# Altium schematic sheet Digital_Clock_SCH_2.0.SchDoc
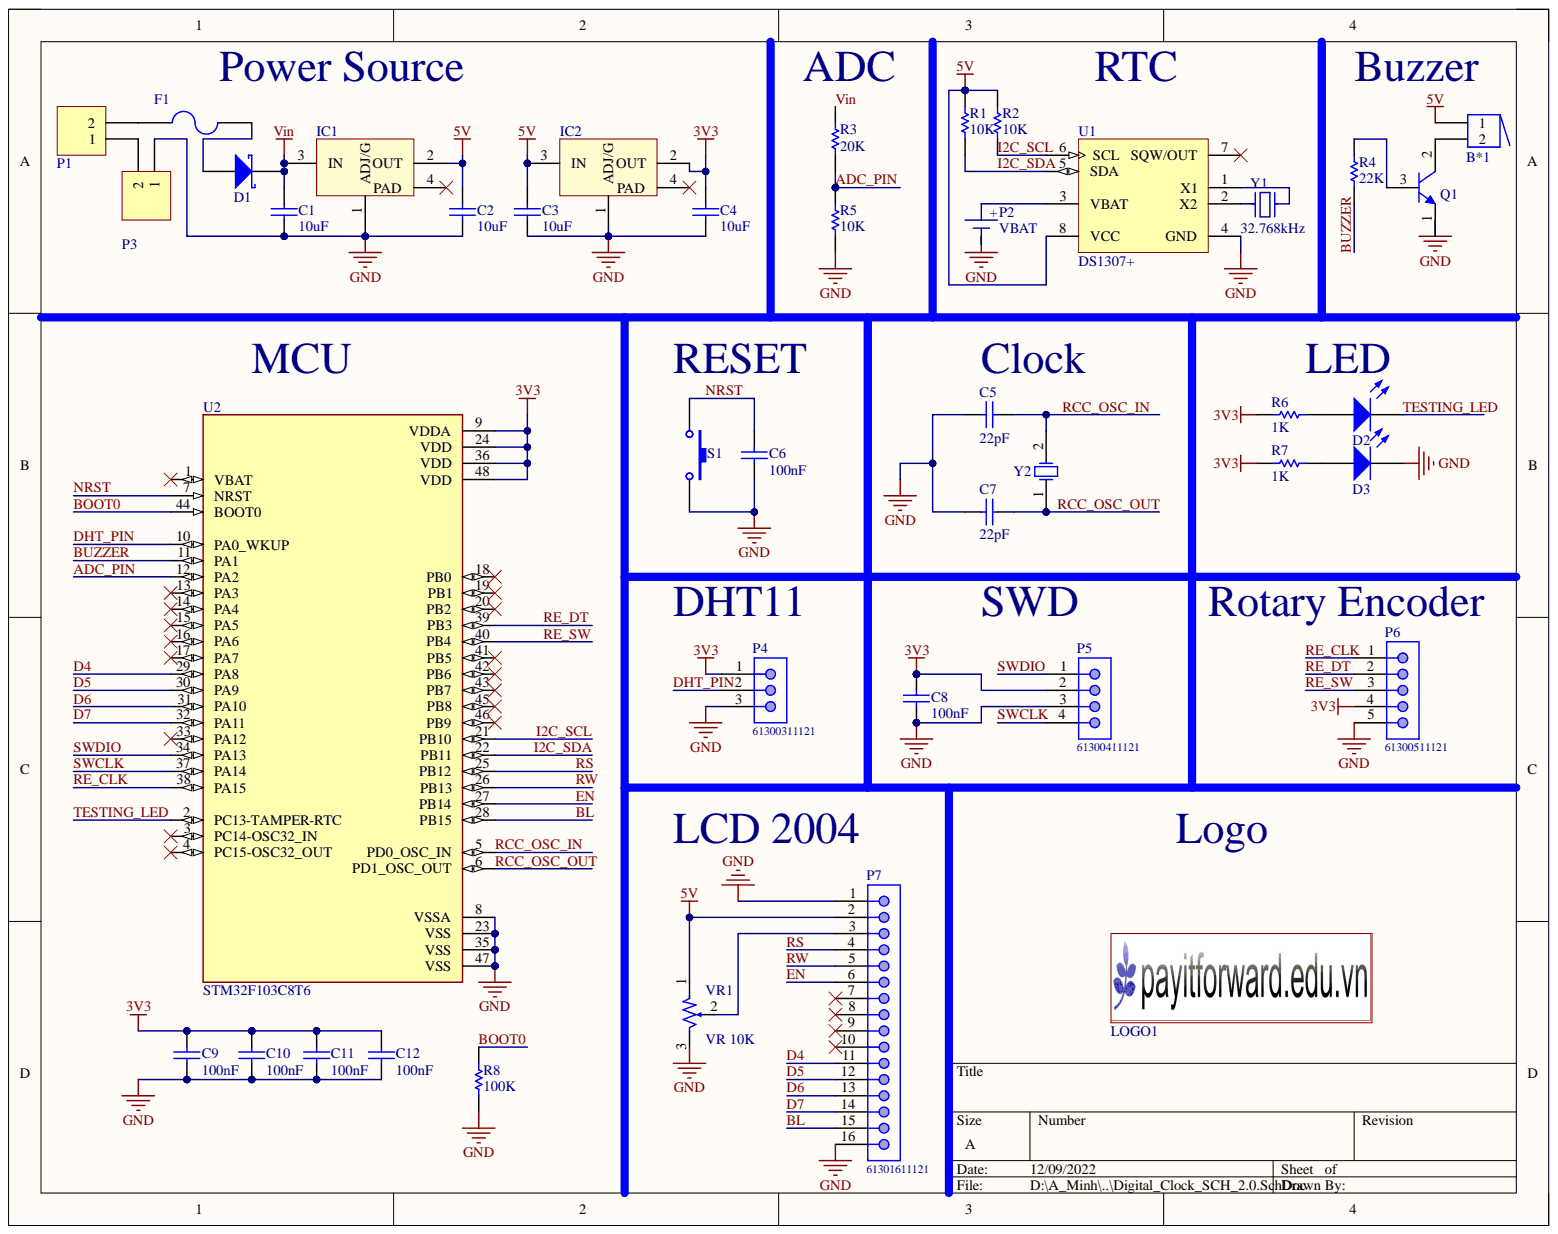
[diagram p0: Digital_Clock_SCH_2.0.SchDoc]

## Parts
B*1  Buzzer  [BUZZER]
C1  value 10uF  [CAP-SM-0805]  (hidden comment: Cap Non-Pol SMD)
C2  value 10uF  [CAP-SM-0805]  (hidden comment: Cap Non-Pol SMD)
C3  value 10uF  [CAP-SM-0805]  (hidden comment: Cap Non-Pol SMD)
C4  value 10uF  [CAP-SM-0805]  (hidden comment: Cap Non-Pol SMD)
C5  value 22pF  [CAP-SM-0603]  (hidden comment: Cap Non-Pol SMD)
C6  value 100nF  [CAP-SM-0603]  (hidden comment: Cap Non-Pol SMD)
C7  value 22pF  [CAP-SM-0603]  (hidden comment: Cap Non-Pol SMD)
C8  value 100nF  [CAP-SM-0603]  (hidden comment: Cap Non-Pol SMD)
C9  value 100nF  [CAP-SM-0603]  (hidden comment: Cap Non-Pol SMD)
C10  value 100nF  [CAP-SM-0603]  (hidden comment: Cap Non-Pol SMD)
C11  value 100nF  [CAP-SM-0603]  (hidden comment: Cap Non-Pol SMD)
C12  value 100nF  [CAP-SM-0603]  (hidden comment: Cap Non-Pol SMD)
D1  D Schottky  [SS34]  (value not drawn)
D2  LED SMD  [LED_0805_Blue]  (value not drawn)
D3  LED SMD  [LED_0805_Blue]  (value not drawn)
F1  Fuse  [FUSE SMD]  (value not drawn)
IC1  LM1117  [SOT223-4N]  (value not drawn)
IC2  LM1117  [SOT223-4N]  (value not drawn)
LOGO1  LOGO PIF  [PIF LOGO BIG SSTOP]  (value not drawn)
P1  Domino 2  [DOMINO SMALL 2]  (value not drawn)
P2  VBAT  [VBAT CR2030]
P3  Domino 2  [DOMINO SMALL 2]  (value not drawn)
P4  61300311121  [61300311121]
P5  61300411121  [61300411121]
P6  61300511121  [61300511121]
P7  61301611121  [61301611121]
Q1  C1815  [SOT23B]  (value not drawn)
R1  value 10K  [RES-SM-0603]  (hidden comment: RESISTOR SMD)
R2  value 10K  [RES-SM-0603]  (hidden comment: RESISTOR SMD)
R3  value 20K  [RES-SM-0603]  (hidden comment: RESISTOR SMD)
R4  value 22K  [RES-SM-0603]  (hidden comment: RESISTOR SMD)
R5  value 10K  [RES-SM-0603]  (hidden comment: RESISTOR SMD)
R6  value 1K  [RES-SM-0603]  (hidden comment: RESISTOR SMD)
R7  value 1K  [RES-SM-0603]  (hidden comment: RESISTOR SMD)
R8  value 100K  [RES-SM-0603]  (hidden comment: RESISTOR SMD)
S1  Tact SW - 2Pin  [SW-SMD]  (value not drawn)
U1  DS1307+  [MAXM-P8-1]
U2  STM32F103C8T6  [QFP50P900X900X160-48N]
VR1  10K  value VR 10K  [Varistor 1]
Y1  32.768kHz  [XTAL 32768 3x8]
Y2  XTAL  [XT2]  (value not drawn)

## Nets
3V3: C4.2 C8.2 C9.2 C10.2 C11.2 C12.2 IC2.2(OUT) P4.1 P5.2 P6.4 R6.1 R7.1 U2.9(VDDA) U2.24(VDD) U2.36(VDD) U2.48(VDD)
5V: B*1.1 C2.2 C3.2 IC1.2(OUT) IC2.3(IN) P7.2 R1.2 R2.2 U1.8(VCC) VR1.1
GND: C1.1 C2.1 C3.1 C4.1 C5.1 C6.1 C7.2 C8.1 C9.1 C10.1 C11.1 C12.1 D3.2 IC1.1(ADJ/G) IC2.1(ADJ/G) P2.2 P3.1 P4.3 P5.3 P6.5 P7.1 P7.16 Q1.1 R5.1 R8.1 S1.2 U1.4(GND) U2.8(VSSA) U2.23(VSS) U2.35(VSS) U2.47(VSS) VR1.3
ADC_PIN: R3.1 R5.2 U2.12(PA2)
BL: P7.15 U2.28(PB15)
BOOT0: R8.2 U2.44(BOOT0)
BUZZER: R4.1 U2.11(PA1)
D4: P7.11 U2.29(PA8)
D5: P7.12 U2.30(PA9)
D6: P7.13 U2.31(PA10)
D7: P7.14 U2.32(PA11)
DHT_PIN: P4.2 U2.10(PA0_WKUP)
EN: P7.6 U2.27(PB14)
I2C_SCL: R2.1 U1.6(SCL) U2.21(PB10)
I2C_SDA: R1.1 U1.5(SDA) U2.22(PB11)
NRST: C6.2 S1.1 U2.7(NRST)
RCC_OSC_IN: C5.2 U2.5(PD0_OSC_IN) Y2.2
RCC_OSC_OUT: C7.1 U2.6(PD1_OSC_OUT) Y2.1
RE_CLK: P6.1 U2.38(PA15)
RE_DT: P6.2 U2.39(PB3)
RE_SW: P6.3 U2.40(PB4)
RS: P7.4 U2.25(PB12)
RW: P7.5 U2.26(PB13)
SWCLK: P5.4 U2.37(PA14)
SWDIO: P5.1 U2.34(PA13)
TESTING_LED: D2.2 U2.2(PC13-TAMPER-RTC)
Vin: C1.2 D1.2 IC1.3(IN) R3.2
NetB*1_2: B*1.2 Q1.2
NetD1_1: D1.1 F1.1
NetD2_1: D2.1 R6.2
NetD3_1: D3.1 R7.2
NetF1_2: F1.2 P1.2
NetP1_1: P1.1 P3.2
NetP2_1: P2.1 U1.3(VBAT)
NetP7_3: P7.3 VR1.2
NetQ1_3: Q1.3 R4.2
NetU1_1: U1.1(X1) Y1.2
NetU1_2: U1.2(X2) Y1.1

Not connected: IC1 4(PAD); IC2 4(PAD); P7 7 8 9 10; U1 7(SQW/OUT); U2 1(VBAT) 3(PC14-OSC32_IN) 4(PC15-OSC32_OUT) 13(PA3) 14(PA4) 15(PA5) 16(PA6) 17(PA7) 18(PB0) 19(PB1) 20(PB2) 33(PA12) 41(PB5) 42(PB6) 43(PB7) 45(PB8) 46(PB9)

## Library data of the parts
C1-C12 = Cap Non-Pol SMD: Capacitor; Component Kind: Standard; Library Name: PIF_Library.IntLib
D1 = D Schottky: Schottky Diode; Code_JEDEC: DO-214-AA; Component Kind: Standard; LatestRevisionDate: 17-Jul-2002; LatestRevisionNote: Re-released for DXP Platform.; Library Name: PIF_Library.IntLib; PackageDescription: DO-214-AA/SMB; 2 C-Bend Leads; Body 5.3 x 3.6 mm, inc. leads (LxW); PackageReference: SMB; Published: 8-Jun-2000; Publisher: Altium Limited
D2 D3 = LED SMD: LED; Component Kind: Standard; Library Name: PIF_Library.IntLib
IC1 IC2 = LM1117: Available in 1.8V, 2.5V, 2.85V, 3.3V, 5V, and  Adjustable Versions; Value: LM317; Component Kind: Standard; Library Name: PIF_Library.IntLib
P1 P3 = Domino 2: Domino, 2-Pin; Component Kind: Standard; LatestRevisionDate: 17-Jul-2002; LatestRevisionNote: Re-released for DXP Platform.; Library Name: PIF_Library.IntLib; Publisher: Altium Limited
P2 = VBAT CR2032: CR2032; Manufacturer: DANTONA INDUSTRIES; Manufacturer Part Number: CR2032 SANYO; Component Kind: Standard; ComponentLink1Description: http://www.farnell.com/datasheets/1797668.pdf; ComponentLink1URL: http://www.farnell.com/datasheets/1797668.pdf; ComponentLink2Description: /datasheets/DANTONA/CRSANYO.pdf; ComponentLink2URL: /datasheets/DANTONA/CRSANYO.pdf; Pricing 1: 1=0.768, 5=0.655, 10=0.615, 25=0.565, 50=0.51, 100=0.46, 250=0.41 (USD); RoHS: NA; Stock 1: 1694; Supplier 1: Newark; Library Name: DeltaCross_Libs.IntLib
P4 = 61300311121: WR-PHD Pin Header, THT, pitch 2.54mm, Single Row, Vertical, 3p; Manufacturer: Wurth Elektronik; Contact Resistance: 20mOhm; Pin Number: 3; Rated Current: 3A; Withstanding Voltage: 500VAC; Working Voltage: 250VAC; Component Kind: Standard; ComponentLink1Description: Manufacturer; ComponentLink1URL: http://www.we-online.de; ComponentLink2Description: Datasheet; ComponentLink2URL: https://www.we-online.com/catalog/datasheet/61300311121.pdf; Gender: Pin Header; Library Name: Altium_WR-PHD (rev20a).IntLib; Manufacturer Part Number: 61300311121; Mounting Technology: THT; Operating Temperature: -40 to +105degC; Orientation: Vertical; Packaging: Bag; Pitch: 2.54mm; Published Date: May 2020; Row Number: Single
P5 = 61300411121: WR-PHD Pin Header, THT, pitch 2.54mm, Single Row, Vertical, 4p; Manufacturer: Wurth Elektronik; Contact Resistance: 20mOhm; Pin Number: 4; Rated Current: 3A; Withstanding Voltage: 500VAC; Working Voltage: 250VAC; Component Kind: Standard; ComponentLink1Description: Manufacturer; ComponentLink1URL: http://www.we-online.de; ComponentLink2Description: Datasheet; ComponentLink2URL: https://www.we-online.com/catalog/datasheet/61300411121.pdf; Gender: Pin Header; Library Name: Altium_WR-PHD (rev20a).IntLib; Manufacturer Part Number: 61300411121; Mounting Technology: THT; Operating Temperature: -40 to +105degC; Orientation: Vertical; Packaging: Bag; Pitch: 2.54mm; Published Date: May 2020; Row Number: Single
P6 = 61300511121: WR-PHD Pin Header, THT, pitch 2.54mm, Single Row, Vertical, 5p; Manufacturer: Wurth Elektronik; Contact Resistance: 20mOhm; Pin Number: 5; Rated Current: 3A; Withstanding Voltage: 500VAC; Working Voltage: 250VAC; Component Kind: Standard; ComponentLink1Description: Manufacturer; ComponentLink1URL: http://www.we-online.de; ComponentLink2Description: Datasheet; ComponentLink2URL: https://www.we-online.com/catalog/datasheet/61300511121.pdf; Gender: Pin Header; Library Name: Altium_WR-PHD (rev20a).IntLib; Manufacturer Part Number: 61300511121; Mounting Technology: THT; Operating Temperature: -40 to +105degC; Orientation: Vertical; Packaging: Bag; Pitch: 2.54mm; Published Date: May 2020; Row Number: Single
P7 = 61301611121: WR-PHD Pin Header, THT, pitch 2.54mm, Single Row, Vertical, 16p; Manufacturer: Wurth Elektronik; Contact Resistance: 20mOhm; Pin Number: 16; Rated Current: 3A; Withstanding Voltage: 500VAC; Working Voltage: 250VAC; Component Kind: Standard; ComponentLink1Description: Manufacturer; ComponentLink1URL: http://www.we-online.de; ComponentLink2Description: Datasheet; ComponentLink2URL: https://www.we-online.com/catalog/datasheet/61301611121.pdf; Gender: Pin Header; Library Name: Altium_WR-PHD (rev20a).IntLib; Manufacturer Part Number: 61301611121; Mounting Technology: THT; Operating Temperature: -40 to +105degC; Orientation: Vertical; Packaging: Bag; Pitch: 2.54mm; Published Date: May 2020; Row Number: Single
Q1 = C1815: NPN Bipolar Transistor; Component Kind: Standard; Library Name: PIF_Library.IntLib
R1-R8 = RESISTOR SMD: Resistor; Component Kind: Standard; Library Name: PIF_Library.IntLib
S1 = Tact SW - 2Pin: Switch button 2 pin; Component Kind: Standard; Library Name: PIF_Library.IntLib
U1 = CMP-016-00004-3: I2C Real-Time Clock, 5 V, 0 to 70 degC, 8-Pin DIP, RoHS, Tube; Manufacturer: Maxim; Interface: Serial; Max Supply Voltage: 5.5V; Min Supply Voltage: 4.5V; Nominal Supply Voltage: 5V; Package Version: Rev. E, 04/2011; Case/Package: DIP; Component Kind: Standard; Datasheet URL: https://datasheet.ciiva.com/20167/82-0567-20167410.pdf; Datasheet Version: Rev. 100208, 10/2008; Manufacturer URL: http://www.maximintegrated.com/; Max Operating Temperature: 70°C; Min Operating Temperature: 0°C; Mounting Technology: TH; Package Description: 8-Pin Plastic Dual-In-Line, Narrow (0.3in) (P8-1), Body 9.375 x 6.985 mm, Pitch 2.54 mm; Package Quantity: 50; Package Reference: P8-1; Package URL: http://pdfserv.maximintegrated.com/package_dwgs/21-0043.PDF; Packaging: Rail/Tube; Pins: 8; RoHS Compliant: True; Library Name: HCMUT
U2 = STM32F103C8T6: Mainstream Performance line, ARM Cortex-M3 MCU with 64 Kbytes Flash, 72 MHz CPU, motor control, USB and CAN; Availability: Unavailable; Component Kind: Standard; Library Name: STM32F103C8T6.IntLib; MP: STM32F103C8T6; Package: None; Price: None
VR1 = Var Resistor: Component Kind: Standard; Description: Var Resistor; Library Name: PIF_Library.IntLib
Y1 = XTAL: Crystal For all Crystal 2pin; Component Kind: Standard; Library Name: DeltaCross_Libs.IntLib
Y2 = XTAL: Crystal Oscillator; Component Kind: Standard; LatestRevisionDate: 17-Jul-2002; LatestRevisionNote: Re-released for DXP Platform.; Library Name: PIF_Library.IntLib; PackageDescription: Crystal, Thru-Hole; 2 Leads; Body 3.1 x 8.2 mm (Dia.xH); PackageReference: R38; Published: 8-Jun-2000; Publisher: Altium Limited
B*1 = Buzzer: Component Kind: Standard; Library Name: PIF_Library.IntLib
F1 = Fuse: Component Kind: Standard; Description: Fuse; Library Name: PIF_Library.IntLib
LOGO1 = LOGO PIF: Component Kind: Standard; Library Name: PIF_Library.IntLib
